# Altium schematic sheet ChopperAmp.SchDoc
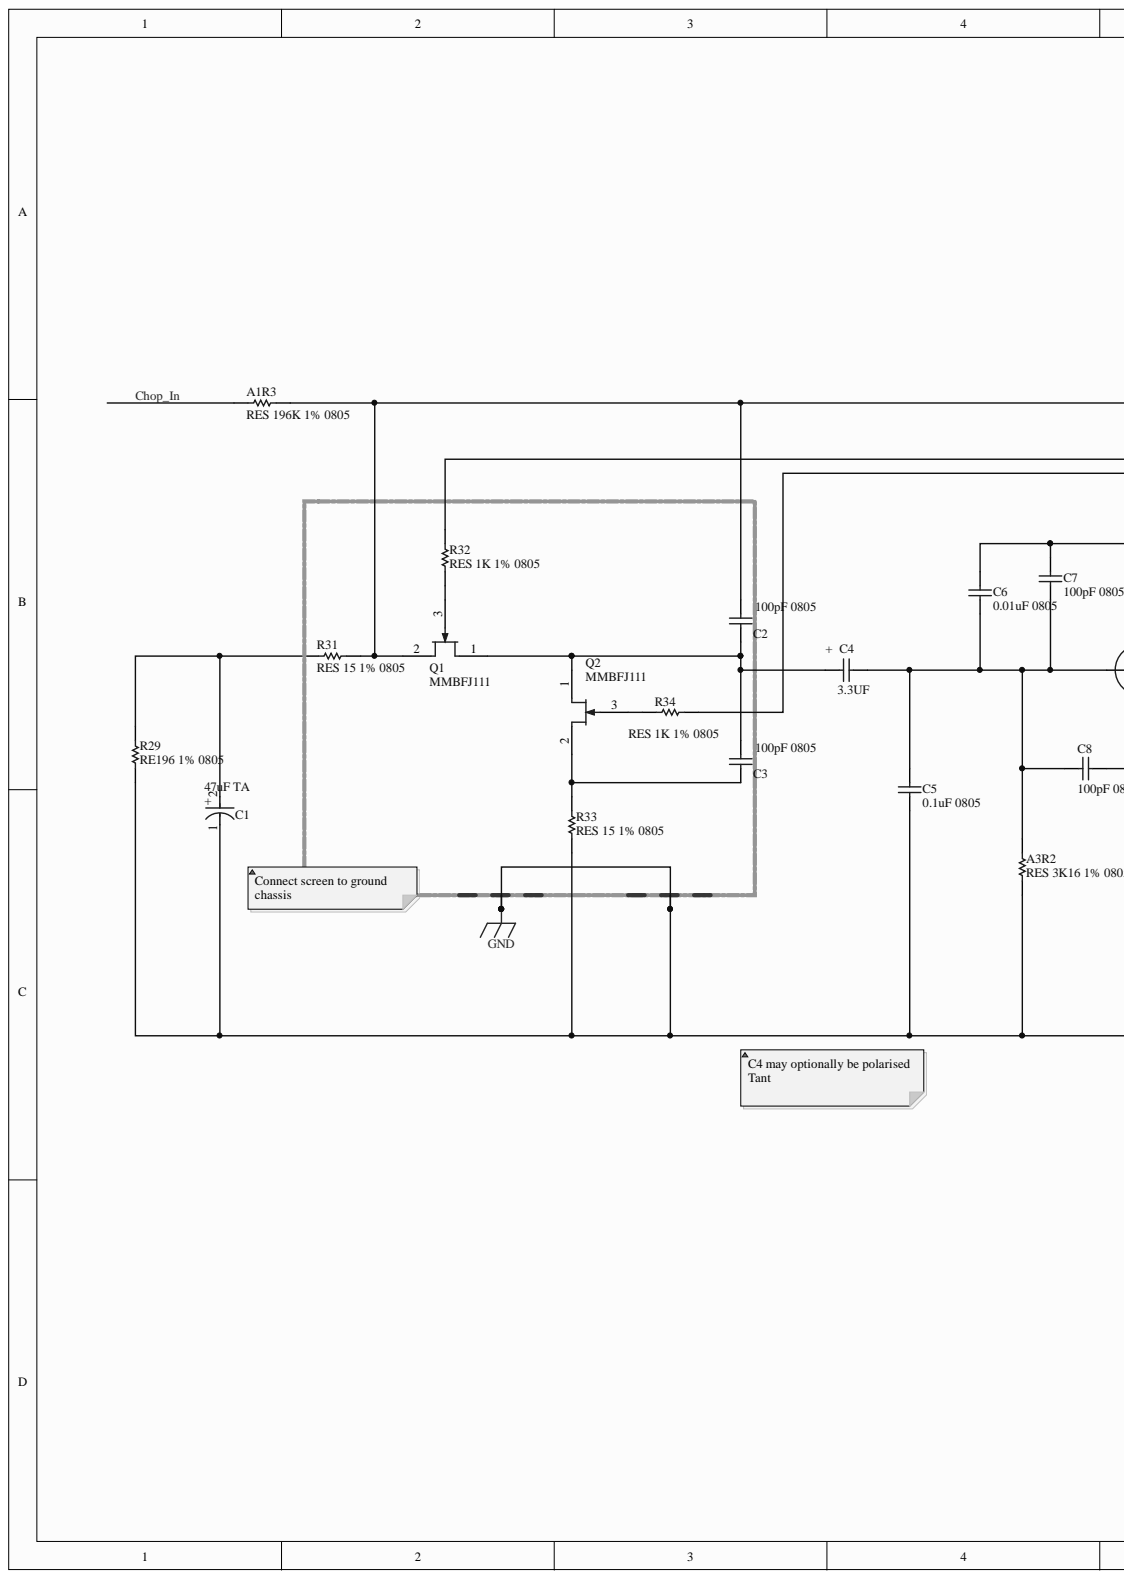
[diagram p0: ChopperAmp.SchDoc, left]
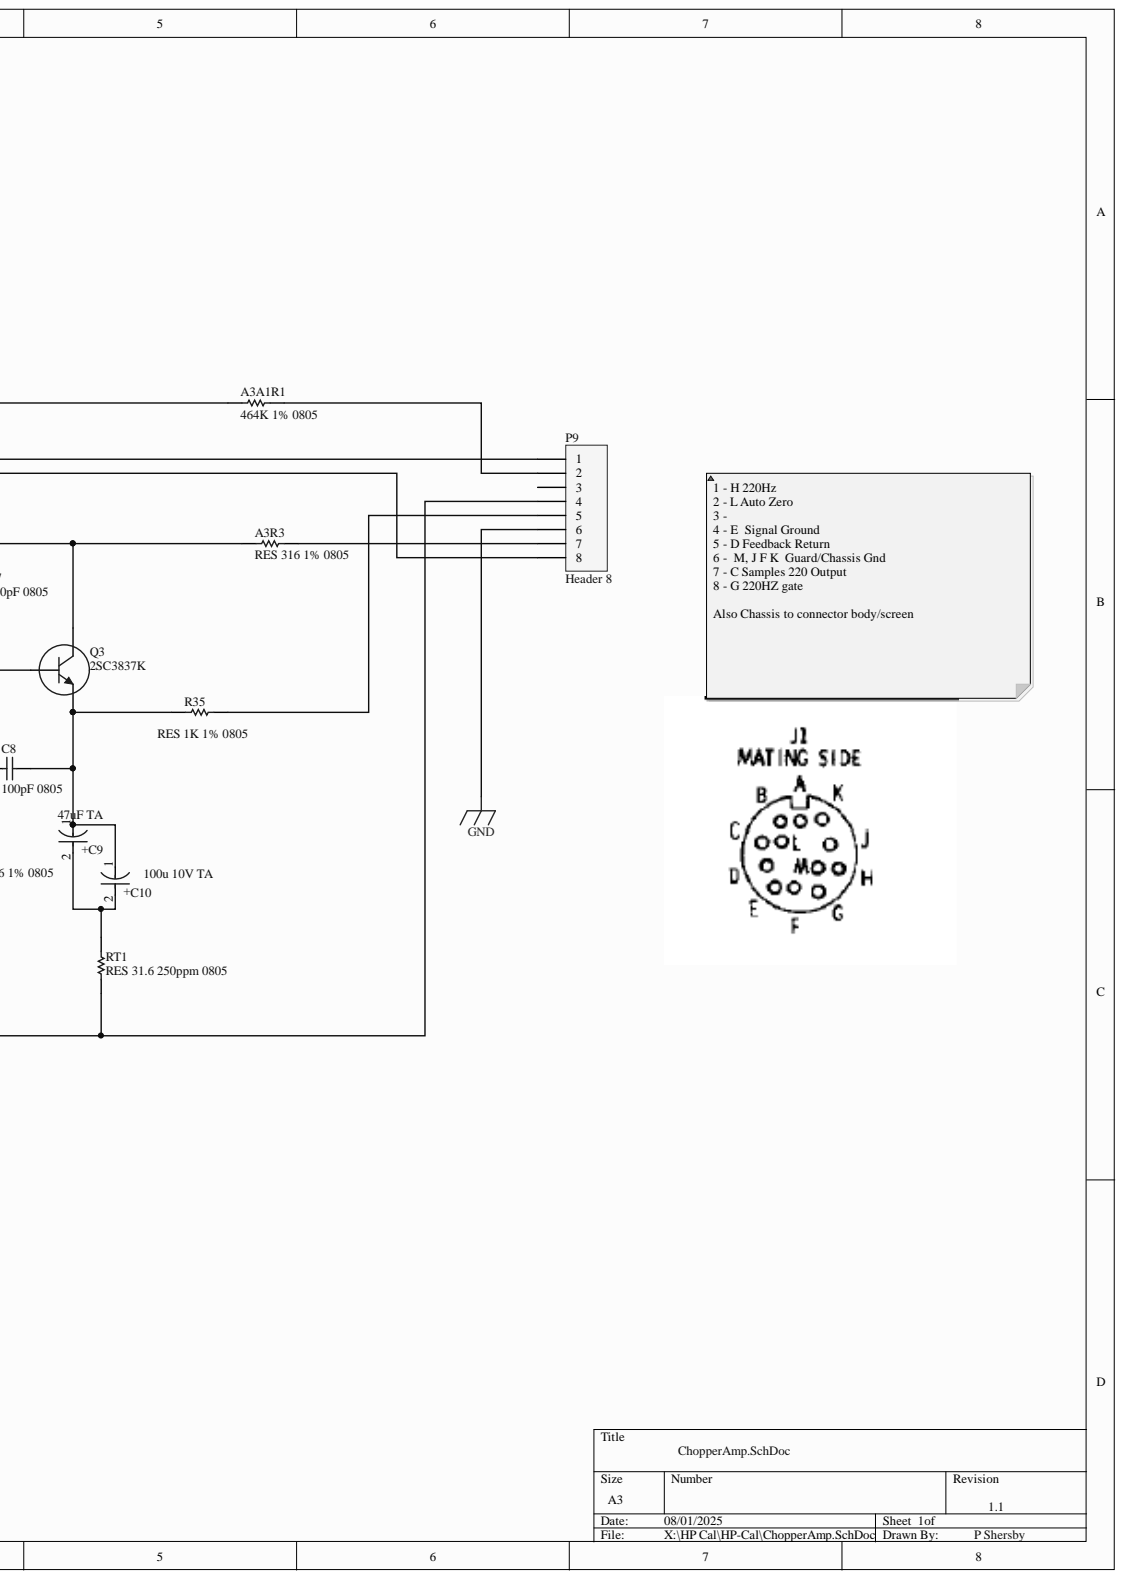
[diagram p1: ChopperAmp.SchDoc, right]

## Parts
A1R3  RES 196K 1% 0805  PackageReference: 0805  [RESC2013X70X40LL20T20]
A3A1R1  464K 1% 0805  PackageReference: 0805  [RESC2013X70X40LL20T20]
A3R2  RES 3K16 1% 0805  [RESC2013X70X40LL20T20]
A3R3  RES 316 1% 0805  PackageReference: 0805  [RESC2013X70X40LL20T20]
C1  47uF TA  [FP-TAJB-MFG]
C2  100pF 0805  [CAPC2113X88X50NL30T25]
C3  100pF 0805  [CAPC2113X88X50NL30T25]
C4  3.3UF  [CAPC1206(3216)150_L]
C5  0.1uF 0805  [CAPC2113X88X50NL30T25]
C6  0.01uF 0805  [CAPC2113X88X50NL30T25]
C7  100pF 0805  [CAPC2113X88X50NL30T25]
C8  100pF 0805  [CAPC2113X88X50NL30T25]
C9  47uF TA  [FP-TAJB-MFG]
C10  100u 10V TA  [FP-TPE-D4-MFG]
P9  Header 8  [HDR1X8]
Q1  MMBFJ111  [ONSC-SOT-23-3-318-08_V]
Q2  MMBFJ111  [ONSC-SOT-23-3-318-08_V]
Q3  2SC3837K  [SC-59]
R29  RE196 1% 0805  [RESC2013X70X40LL20T20]
R31  RES 15 1% 0805  [RESC2013X70X40LL20T20]
R32  RES 1K 1% 0805  [RESC2013X70X40LL20T20]
R33  RES 15 1% 0805  [RESC2013X70X40LL20T20]
R34  RES 1K 1% 0805  PackageReference: 0805  [RESC2013X70X40LL20T20]
R35  RES 1K 1% 0805  PackageReference: 0805  [RESC2013X70X40LL20T20]
RT1  RES 31.6 250ppm 0805  [RESC2013X70X40LL20T20]
SC1  ScreenConnection  [ScreenConnection]  (value not drawn)
SC2  ScreenConnection  [ScreenConnection]  (value not drawn)

## Nets
GND: A3R2.1 C1.1 C5.2 P9.4 P9.6 R29.2 R33.2 RT1.1 SC1.1 SC2.1
CHOP_IN: A1R3.1
NetA1R3_2: A1R3.2 A3A1R1.1 C2.2 Q1.2 R31.1
NetA3A1R1_2: A3A1R1.2 P9.2
NetA3R2_2: A3R2.2 C4.2 C5.1 C6.2 C7.2 C8.2 Q3.2
NetA3R3_1: A3R3.1 C6.1 C7.1 Q3.1
NetA3R3_2: A3R3.2 P9.7
NetC1_2: C1.2 R29.1 R31.2
NetC2_1: C2.1 C3.2 C4.1 Q1.1 Q2.1
NetC3_1: C3.1 Q2.2 R33.1
NetC8_1: C8.1 C9.1 C10.1 Q3.3 R35.1
NetC9_2: C9.2 C10.2 RT1.2
NetP9_1: P9.1 R32.1
NetP9_5: P9.5 R35.2
NetP9_8: P9.8 R34.2
NetQ1_3: Q1.3 R32.2
NetQ2_3: Q2.3 R34.1

Not connected: P9 3

## Library data of the parts
A1R3 = CMP-2001-00110-5: Resistor 196K 1% 0805  1/8W 10ppm/C; Manufacturer: Panasonic; Voltage Rating: 150 V; Component Kind: Standard; ComponentLink1Description: Manufacturer URL; ComponentLink1URL: http://www.panasonic.com/; ComponentLink2Description: Datasheet; ComponentLink2URL: http://industrial.panasonic.com/cdbs/www-data/pdf/RDA0000/AOA0000C86.pdf; DatasheetVersion: 04/2015; Height: 0.6 mm; Length: 2 mm; Library Name: Altium Content Vault; Max Operating Temperature: 155 degC; Min Operating Temperature: -55 degC; Mount: Surface Mount; Mounting Technology: Surface Mount; PackageDescription: 2-Pin Surface Mount Device, Body 2 x 1.25 mm; Package Quantity: 5000; Packaging: Tape and Reel; REACH SVHC: Yes; RoHSCompliant: true
A3A1R1 = CMP-2001-00110-5: Resistor 464K 1% 0805   0.2W 10ppm/C; Manufacturer: Panasonic; Voltage Rating: 150 V; Component Kind: Standard; ComponentLink1Description: Manufacturer URL; ComponentLink1URL: http://www.panasonic.com/; ComponentLink2Description: Datasheet; ComponentLink2URL: http://industrial.panasonic.com/cdbs/www-data/pdf/RDA0000/AOA0000C86.pdf; DatasheetVersion: 04/2015; Height: 0.6 mm; Length: 2 mm; Library Name: Altium Content Vault; Max Operating Temperature: 155 degC; Min Operating Temperature: -55 degC; Mount: Surface Mount; Mounting Technology: Surface Mount; PackageDescription: 2-Pin Surface Mount Device, Body 2 x 1.25 mm; Package Quantity: 5000; Packaging: Tape and Reel; REACH SVHC: Yes; RoHSCompliant: true
A3R2 = CMP-2001-00110-5: Resistor 3K!6 1% 0805  1/8W 10ppm/C; Manufacturer: Panasonic; Voltage Rating: 150 V; Component Kind: Standard; ComponentLink1Description: Manufacturer URL; ComponentLink1URL: http://www.panasonic.com/; ComponentLink2Description: Datasheet; ComponentLink2URL: http://industrial.panasonic.com/cdbs/www-data/pdf/RDA0000/AOA0000C86.pdf; DatasheetVersion: 04/2015; Height: 0.6 mm; Length: 2 mm; Library Name: Altium Content Vault; Max Operating Temperature: 155 degC; Min Operating Temperature: -55 degC; Mount: Surface Mount; Mounting Technology: Surface Mount; PackageDescription: 2-Pin Surface Mount Device, Body 2 x 1.25 mm; Package Quantity: 5000; PackageReference: 0805; Packaging: Tape and Reel; REACH SVHC: Yes; RoHSCompliant: true
A3R3 = CMP-2001-00110-5: Resistor 316 1% 0805  1/8W 10ppm/C; Manufacturer: Panasonic; Voltage Rating: 150 V; Component Kind: Standard; ComponentLink1Description: Manufacturer URL; ComponentLink1URL: http://www.panasonic.com/; ComponentLink2Description: Datasheet; ComponentLink2URL: http://industrial.panasonic.com/cdbs/www-data/pdf/RDA0000/AOA0000C86.pdf; DatasheetVersion: 04/2015; Height: 0.6 mm; Length: 2 mm; Library Name: Altium Content Vault; Max Operating Temperature: 155 degC; Min Operating Temperature: -55 degC; Mount: Surface Mount; Mounting Technology: Surface Mount; PackageDescription: 2-Pin Surface Mount Device, Body 2 x 1.25 mm; Package Quantity: 5000; Packaging: Tape and Reel; REACH SVHC: Yes; RoHSCompliant: true
C1 C9 = CMP-04424-000035-1: 47uF Tant 4V 1411; Manufacturer: AVX Corporation; Manufacturer Part Number: TAJB475K010RNJ; Capacitance: 4.7uF; Dissipation Factor: 6%; Esr: 4Ω; Life: 1000Hours; Material: Tantalum; Ripple Current: 146mA; Tolerance: 10%; Value: 4.7uF; Voltage Rating: 10V; Automotive: No; Category: Cap; Component Kind: Standard; ComponentLink2Description: Datasheet; ComponentLink2URL: http://datasheets.avx.com/TAJ.pdf; Device Class L1: Passive Components; Device Class L2: Capacitors; Device Class L3: Tantalum Capacitors; Digikey Description: CAP TANT 4.7UF 10% 10V 1411; Footprint Url: https://www.avx.com/docs/techinfo/TechSumAppGuide.pdf; Height: 2.1mm; Lead Free: Yes; Library Name: Altium Content Vault; Mouser Description: Cap Tant Solid 4.7uF 10V B CASE 10% (3.5 X 2.8 X 1.9mm) SMD 3528-21 4 Ohm 125 C T/R; Octopart: https://octopart.com/tajb475k010rnj-avx-2636133; Package: TAJB; RoHS: TRUE; Temperature: -55°C to +125°C; Temperature Range High: +125°C; Temperature Range Low: -55°C
C2 C3 C5-C8 = CMP-2007-00001-1: Manufacturer: Kemet; Part Number: C0805X474K4RACTU; Component Kind: Standard; Library Name: Altium Content Vault
  C2 C3 C7 C8: Description: 100pF 0805
  C5: Description: 0.1uF 0805
  C6: Description: 0.01uF 0805
C4 = CMP-1590-00001-1: 1206 (3216 Metric) Chip Capacitor; Case-EIA: 1206; Case-Metric: 3216; Component Kind: Standard; Library Name: Altium Content Vault; PackageReference: CAPC1206(3216)150
C10 = CMP-05428-000010-1: CAP TANT 100u 10V; Manufacturer: Panasonic Electronic; Manufacturer Part Number: 6TPE330MAA; Capacitance: 330uF; Dissipation Factor: 10%; Esr: 10mΩ; Leakage Current: 207.9uA; Life: 1000Hours; Material: Polymer; Ripple Current: 4400mA; Tolerance: 20%; Value: 330uF; Voltage Rating: 6.3V; Automotive: No; Category: Cap; Component Kind: Standard; ComponentLink2Description: Datasheet; ComponentLink2URL: https://industrial.panasonic.com/cdbs/www-data/pdf/AAA8000/AAA8000C36.pdf; Device Class L1: Passive Components; Device Class L2: Capacitors; Device Class L3: Polymer Capacitors; Digikey Description: CAP TANT POLY 330UF 6.3V 2917; Footprint Url: https://industrial.panasonic.com/cdbs/www-data/pdf/AAA8000/AAA8000COL85.pdf; Height: 4mm; Lead Free: Yes; Library Name: Altium Content Vault; Mouser Description: Tantalum Capacitors - Polymer SMD 6.3volts 330uF ESR 10mohm; Octopart: https://octopart.com/6tpe330maa-panasonic-29487875; Package: TPE-D4; RoHS: TRUE; Temperature: -55°C to +105°C; Temperature Range High: +105°C; Temperature Range Low: -55°C
P9 = Header 8: Header, 8-Pin; Component Kind: Standard; LatestRevisionDate: 17-Jul-2002; LatestRevisionNote: Re-released for DXP Platform.; Library Name: Miscellaneous Connectors.IntLib; Publisher: Altium Limited
Q1 Q2 = CMP-1062-00038-1: JFET - VHF / UJFET Chopper; Manufacturer: On Semiconductor; PartNumber: MMBFJ310LT3; Channel Polarity: N Channel; Ciss Max (pF): 5; Crss Max (pF): 2.5; IDSS Max (uA): 60000; IDSS Min (uA): 24000; Packing: Tape and Reel; V(BR)GSS Min (V): 25; Component Kind: Standard; ComponentLink1Description: Manufacturer URL; ComponentLink1URL: http://www.onsemi.com/; ComponentLink2Description: Datasheet; ComponentLink2URL: http://www.onsemi.cn/pub_link/Collateral/MMBFJ309LT1-D.PDF; ComponentLink3Description: Package Specification; ComponentLink3URL: http://www.onsemi.cn/pub_link/Collateral/318-08.PDF; DatasheetVersion: Rev. 7; Library Name: Altium Content Vault; Mounting Technology: Surface Mount; PackageDescription: 3-Pin Small Outline Transistor, Body 2.92 x 1.3 mm, Pitch 0.95 mm; PackageReference: SOT-23-3-318-08; PackageVersion: Rev. AP, 11/2009
Q3 = NPN: Component Kind: Standard; Description: 2SC3837K; Library Name: Discrete Semi.SchLib
R29 = CMP-2001-00110-5: Resistor 196 1% 0805  0.2W 10ppm/C; Manufacturer: Panasonic; Voltage Rating: 150 V; Component Kind: Standard; ComponentLink1Description: Manufacturer URL; ComponentLink1URL: http://www.panasonic.com/; ComponentLink2Description: Datasheet; ComponentLink2URL: http://industrial.panasonic.com/cdbs/www-data/pdf/RDA0000/AOA0000C86.pdf; DatasheetVersion: 04/2015; Height: 0.6 mm; Length: 2 mm; Library Name: Altium Content Vault; Max Operating Temperature: 155 degC; Min Operating Temperature: -55 degC; Mount: Surface Mount; Mounting Technology: Surface Mount; PackageDescription: 2-Pin Surface Mount Device, Body 2 x 1.25 mm; Package Quantity: 5000; PackageReference: 0805; Packaging: Tape and Reel; REACH SVHC: Yes; RoHSCompliant: true
R31 R33 = CMP-2001-00110-5: Resistor 15 1% 0805  0.2W 10ppm/C; Manufacturer: Panasonic; Voltage Rating: 150 V; Component Kind: Standard; ComponentLink1Description: Manufacturer URL; ComponentLink1URL: http://www.panasonic.com/; ComponentLink2Description: Datasheet; ComponentLink2URL: http://industrial.panasonic.com/cdbs/www-data/pdf/RDA0000/AOA0000C86.pdf; DatasheetVersion: 04/2015; Height: 0.6 mm; Length: 2 mm; Library Name: Altium Content Vault; Max Operating Temperature: 155 degC; Min Operating Temperature: -55 degC; Mount: Surface Mount; Mounting Technology: Surface Mount; PackageDescription: 2-Pin Surface Mount Device, Body 2 x 1.25 mm; Package Quantity: 5000; PackageReference: 0805; Packaging: Tape and Reel; REACH SVHC: Yes; RoHSCompliant: true
R32 = CMP-2001-00110-5: Resistor 1K 1% 0805  0.2W 10ppm/C; Manufacturer: Panasonic; Voltage Rating: 150 V; Component Kind: Standard; ComponentLink1Description: Manufacturer URL; ComponentLink1URL: http://www.panasonic.com/; ComponentLink2Description: Datasheet; ComponentLink2URL: http://industrial.panasonic.com/cdbs/www-data/pdf/RDA0000/AOA0000C86.pdf; DatasheetVersion: 04/2015; Height: 0.6 mm; Length: 2 mm; Library Name: Altium Content Vault; Max Operating Temperature: 155 degC; Min Operating Temperature: -55 degC; Mount: Surface Mount; Mounting Technology: Surface Mount; PackageDescription: 2-Pin Surface Mount Device, Body 2 x 1.25 mm; Package Quantity: 5000; PackageReference: 0805; Packaging: Tape and Reel; REACH SVHC: Yes; RoHSCompliant: true
R34 R35 = CMP-2001-00110-5: Resistor 1K1% 0805  0.2W 10ppm/C; Manufacturer: Panasonic; Voltage Rating: 150 V; Component Kind: Standard; ComponentLink1Description: Manufacturer URL; ComponentLink1URL: http://www.panasonic.com/; ComponentLink2Description: Datasheet; ComponentLink2URL: http://industrial.panasonic.com/cdbs/www-data/pdf/RDA0000/AOA0000C86.pdf; DatasheetVersion: 04/2015; Height: 0.6 mm; Length: 2 mm; Library Name: Altium Content Vault; Max Operating Temperature: 155 degC; Min Operating Temperature: -55 degC; Mount: Surface Mount; Mounting Technology: Surface Mount; PackageDescription: 2-Pin Surface Mount Device, Body 2 x 1.25 mm; Package Quantity: 5000; Packaging: Tape and Reel; REACH SVHC: Yes; RoHSCompliant: true
RT1 = CMP-2001-00110-5: Manufacturer: Panasonic; Voltage Rating: 150 V; Component Kind: Standard; ComponentLink1Description: Manufacturer URL; ComponentLink1URL: http://www.panasonic.com/; ComponentLink2Description: Datasheet; ComponentLink2URL: http://industrial.panasonic.com/cdbs/www-data/pdf/RDA0000/AOA0000C86.pdf; DatasheetVersion: 04/2015; Description: RES 31.6 250ppm 0805; Height: 0.6 mm; Length: 2 mm; Library Name: Altium Content Vault; Max Operating Temperature: 155 degC; Min Operating Temperature: -55 degC; Mount: Surface Mount; Mounting Technology: Surface Mount; PackageDescription: 2-Pin Surface Mount Device, Body 2 x 1.25 mm; Package Quantity: 5000; PackageReference: 0805; Packaging: Tape and Reel; REACH SVHC: Yes; RoHSCompliant: true
SC1 SC2 = ScreenConnection: Component Kind: Standard; Library Name: Mechanical.SchLib
